# Altium schematic sheet UBX_NORA_B1_SCHEM_0006_Accessories.SchDoc
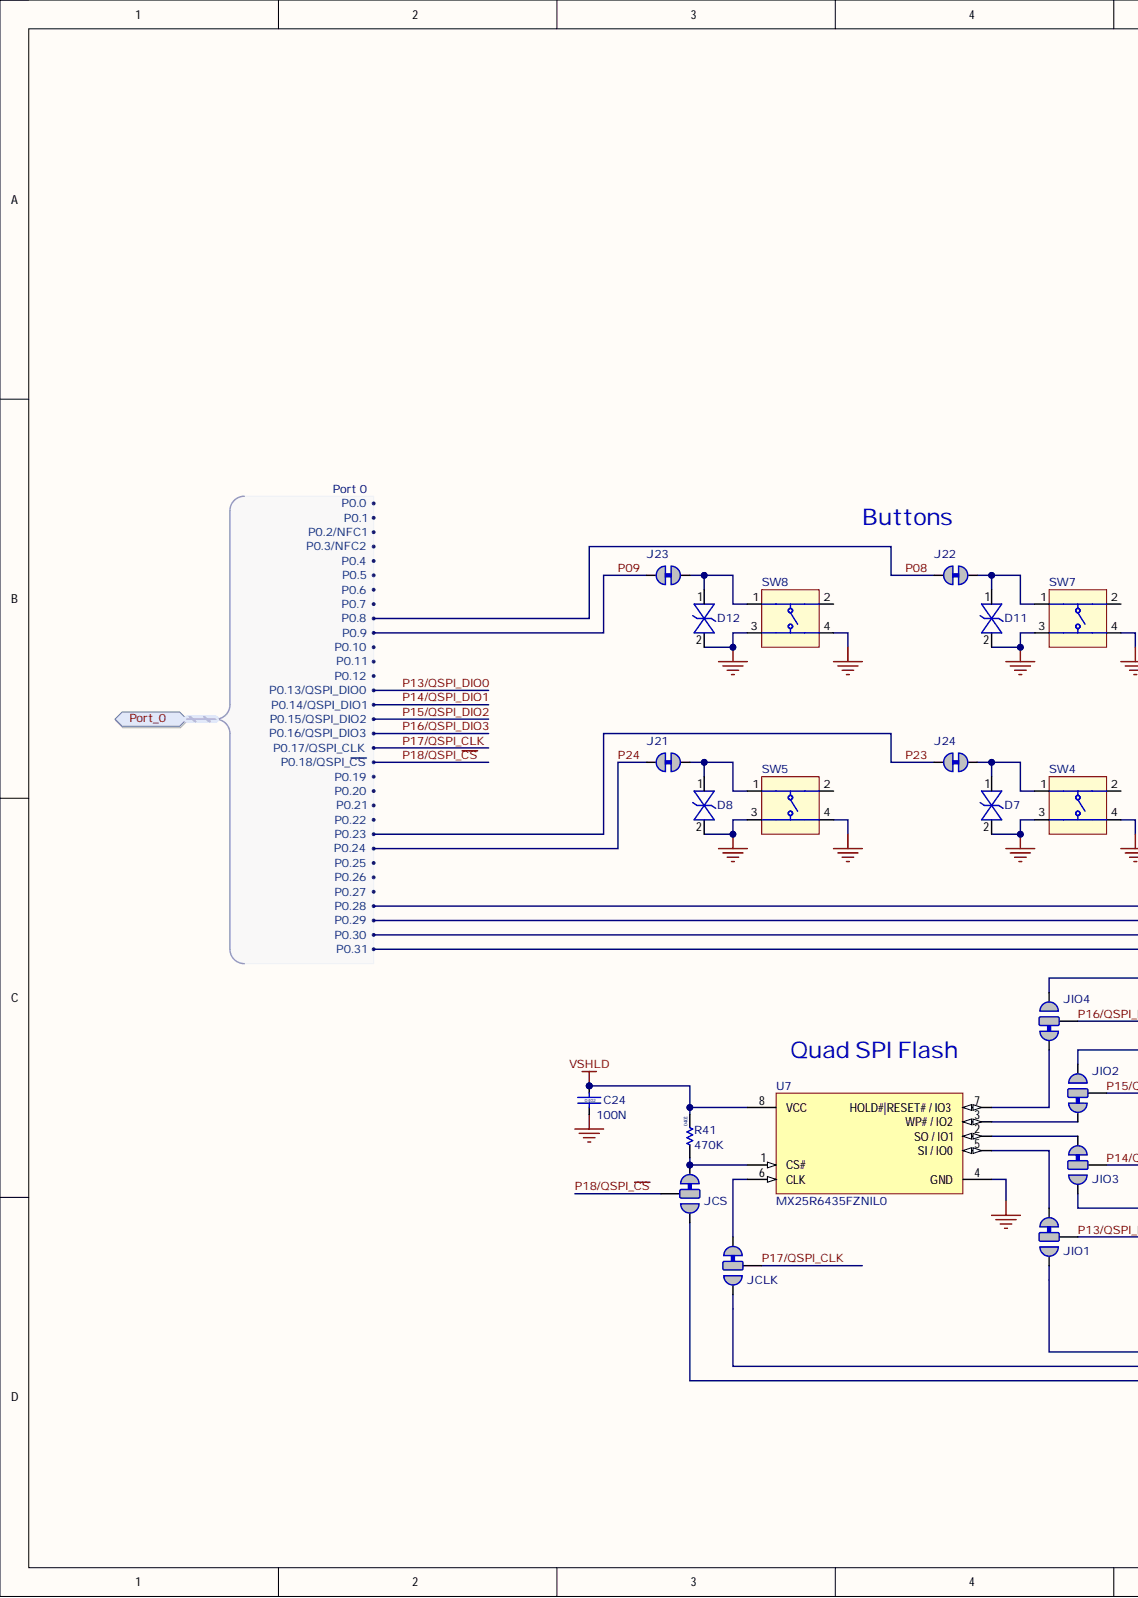
[diagram p0: UBX_NORA_B1_SCHEM_0006_Accessories.SchDoc, left]
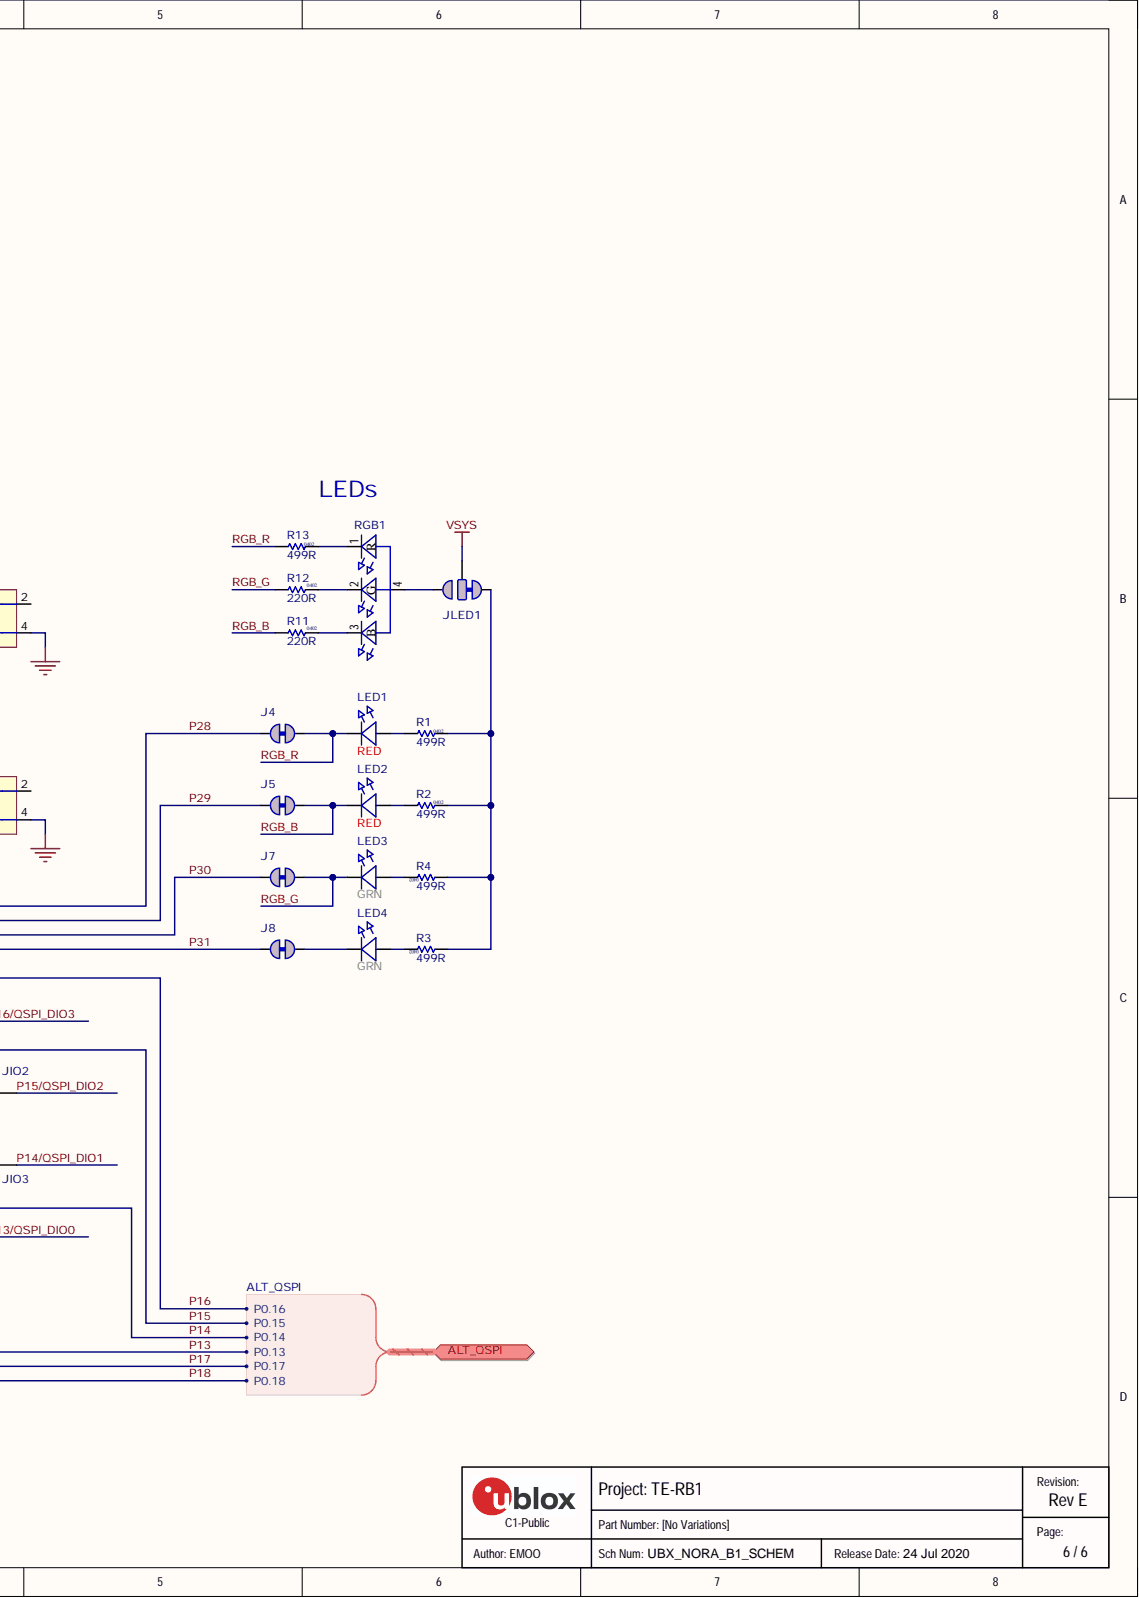
[diagram p1: UBX_NORA_B1_SCHEM_0006_Accessories.SchDoc, right]

## Parts
C24  value 100N  Size: 0402  [Cap 0402 HD]  (hidden comment: UBXH01-2021002100N)
D7  UBXH07-0000034  [SOD-923]  (value not drawn)
D8  UBXH07-0000034  [SOD-923]  (value not drawn)
D11  UBXH07-0000034  [SOD-923]  (value not drawn)
D12  UBXH07-0000034  [SOD-923]  (value not drawn)
J4  UBXH00-0000084  [Solderbridge-Short]  (value not drawn)
J5  UBXH00-0000084  [Solderbridge-Short]  (value not drawn)
J7  UBXH00-0000084  [Solderbridge-Short]  (value not drawn)
J8  UBXH00-0000084  [Solderbridge-Short]  (value not drawn)
J21  UBXH00-0000084  [Solderbridge-Short]  (value not drawn)
J22  UBXH00-0000084  [Solderbridge-Short]  (value not drawn)
J23  UBXH00-0000084  [Solderbridge-Short]  (value not drawn)
J24  UBXH00-0000084  [Solderbridge-Short]  (value not drawn)
JCLK  UBXH00-0000083  [Solderbridge-Option]  (value not drawn)
JCS  UBXH00-0000083  [Solderbridge-Option]  (value not drawn)
JIO1  UBXH00-0000083  [Solderbridge-Option]  (value not drawn)
JIO2  UBXH00-0000083  [Solderbridge-Option]  (value not drawn)
JIO3  UBXH00-0000083  [Solderbridge-Option]  (value not drawn)
JIO4  UBXH00-0000083  [Solderbridge-Option]  (value not drawn)
JLED1  UBXH00-0000083  [Solderbridge-Option]  (value not drawn)
LED1  value RED  Color: RED  [LED_0603_RED]  (hidden comment: UBXH11-0000000)
LED2  value RED  Color: RED  [LED_0603_RED]  (hidden comment: UBXH11-0000000)
LED3  Color: GRN  [LED_0603_GREEN]  (hidden comment: UBXH11-0000001)
LED4  Color: GRN  [LED_0603_GREEN]  (hidden comment: UBXH11-0000001)
R1  value 499R  Size: 0402  [RES 0402 HD]  (hidden comment: UBXH18-0000269499R)
R2  value 499R  Size: 0402  [RES 0402 HD]  (hidden comment: UBXH18-0000269499R)
R3  value 499R  Size: 0402  [RES 0402 HD]  (hidden comment: UBXH18-0000269499R)
R4  value 499R  Size: 0402  [RES 0402 HD]  (hidden comment: UBXH18-0000269499R)
R11  value 220R  Size: 0402  [RES 0402 HD]  (hidden comment: UBXH18-0008033220R)
R12  value 220R  Size: 0402  [RES 0402 HD]  (hidden comment: UBXH18-0008033220R)
R13  value 499R  Size: 0402  [RES 0402 HD]  (hidden comment: UBXH18-0000269499R)
R41  value 470K  Size: 0402  [RES 0402 HD]  (hidden comment: UBXH18-0008113470K)
RGB1  UBXH11-0000058  [LED_0606_RGB_4PIN]  (value not drawn)
SW4  UBXH19-0000035  [SW_TACT_SMT_6mm]  (value not drawn)
SW5  UBXH19-0000035  [SW_TACT_SMT_6mm]  (value not drawn)
SW7  UBXH19-0000035  [SW_TACT_SMT_6mm]  (value not drawn)
SW8  UBXH19-0000035  [SW_TACT_SMT_6mm]  (value not drawn)
U7  value MX25R6435FZNIL0  Manufacturer P/N: MX25R6435FZNIL0  [WSON1.27P-8Pin_W-Pad]  (hidden comment: UBXH13-0000138)

## Ports
ALT_QSPI, ALT_QSPI.P0.13, ALT_QSPI.P0.14, ALT_QSPI.P0.15, ALT_QSPI.P0.16, ALT_QSPI.P0.17, ALT_QSPI.P0.18, Port_0, Port_0.P0.0, Port_0.P0.1, Port_0.P0.2/NFC1, Port_0.P0.3/NFC2, Port_0.P0.4, Port_0.P0.5, Port_0.P0.6, Port_0.P0.7, Port_0.P0.8, Port_0.P0.9, Port_0.P0.10, Port_0.P0.11, Port_0.P0.12, Port_0.P0.13/QSPI_DIO0, Port_0.P0.14/QSPI_DIO1, Port_0.P0.15/QSPI_DIO2, Port_0.P0.16/QSPI_DIO3, Port_0.P0.17/QSPI_CLK, Port_0.P0.18/QSPI_~{CS}, Port_0.P0.19, Port_0.P0.20, Port_0.P0.21, Port_0.P0.22, Port_0.P0.23, Port_0.P0.24, Port_0.P0.25, Port_0.P0.26, Port_0.P0.27, Port_0.P0.28, Port_0.P0.29, Port_0.P0.30, Port_0.P0.31

## Nets
GND: C24.2 D7.2 D8.2 D11.2 D12.2 SW4.3 SW4.4 SW5.3 SW5.4 SW7.3 SW7.4 SW8.3 SW8.4 U7.4(GND)
VSHLD: C24.1 R41.2 U7.8(VCC)
VSYS: JLED1.2
P08: J22.2 ; port Port_0
P09: J23.2 ; port Port_0
P13: JIO1.3 ; port ALT_QSPI
P13/QSPI_DIO0: JIO1.2 ; port Port_0
P14: JIO3.3 ; port ALT_QSPI
P14/QSPI_DIO1: JIO3.2 ; port Port_0
P15: JIO2.3 ; port ALT_QSPI
P15/QSPI_DIO2: JIO2.2 ; port Port_0
P16: JIO4.3 ; port ALT_QSPI
P16/QSPI_DIO3: JIO4.2 ; port Port_0
P17: JCLK.3 ; port ALT_QSPI
P17/QSPI_CLK: JCLK.2 ; port Port_0
P18: JCS.3 ; port ALT_QSPI
P18/QSPI_~{CS}: JCS.2 ; port Port_0
P23: J24.2 ; port Port_0
P24: J21.2 ; port Port_0
P28: J4.2 ; port Port_0
P29: J5.2 ; port Port_0
P30: J7.2 ; port Port_0
P31: J8.2 ; port Port_0
RGB_B: J5.1 LED2.1 R11.1
RGB_G: J7.1 LED3.1 R12.1
RGB_R: J4.1 LED1.1 R13.1
NetD7_1: D7.1 J24.1 SW4.1
NetD8_1: D8.1 J21.1 SW5.1
NetD11_1: D11.1 J22.1 SW7.1
NetD12_1: D12.1 J23.1 SW8.1
NetJ8_1: J8.1 LED4.1
NetJCLK_1: JCLK.1 U7.6(CLK)
NetJCS_1: JCS.1 R41.1 U7.1(CS#)
NetJIO1_1: JIO1.1 U7.5(SI_/_IO0)
NetJIO2_1: JIO2.1 U7.3(WP#_/_IO2)
NetJIO3_1: JIO3.1 U7.2(SO_/_IO1)
NetJIO4_1: JIO4.1 U7.7(HOLD#|RESET#_/_IO3)
NetJLED1_1: JLED1.1 R1.2 R2.2 R3.1 R4.1
NetJLED1_3: JLED1.3 RGB1.4
NetLED1_2: LED1.2 R1.1
NetLED2_2: LED2.2 R2.1
NetLED3_2: LED3.2 R4.2
NetLED4_2: LED4.2 R3.2
NetR11_2: R11.2 RGB1.3(B)
NetR12_2: R12.2 RGB1.2(G)
NetR13_2: R13.2 RGB1.1(R)
N00001: ; port Port_0
N00002: ; port Port_0
N00003: ; port Port_0
N00004: ; port Port_0
N00005: ; port Port_0
N00006: ; port Port_0
N00007: ; port Port_0
N00008: ; port Port_0
N00009: ; port Port_0
N00010: ; port Port_0
N00011: ; port Port_0
N00012: ; port Port_0
N00013: ; port Port_0
N00014: ; port Port_0
N00015: ; port Port_0
N00016: ; port Port_0
N00017: ; port Port_0
N00018: ; port Port_0

Not connected: SW4 2; SW5 2; SW7 2; SW8 2

## Library data of the parts
C24 = UBXH01-2021002: CAP CER X5R 0402 100N 10% 10V; Manufacturer: Murata Manufacturing Company, Ltd.; Manufacturer P/N: GRM155R61A104KA01; Database Table Name: Passive - Capacitor; Editor: roland.rust; Env: GREEN; Mfg P/N Released: Yes; Mfg P/N Split: 100; Mfg P/N Status: NRND; Perferred: PREF; Permitted Use: Volume Products; Component Kind: Standard; Library Name: UBX_Parts_DB_Lib.DbLib; Manufacturer 2: Samsung Electro-Mechanics; Manufacturer P/N 2: CL05A104KP5NNN; Max Voltage: 10V; Mfg P/N Datasheet 2: https://wiki.u-blox.com/bin/view/Generic/Docs/DocumentSummary?index=28113; Mfg P/N Released 2: Yes; Mfg P/N Split 2: 0; Mfg P/N Status 2: Active; Part Number: UBXH01-2021002; Part Type: Capacitor; RefDes: C; Release Data: 2005-07-22T13:59:44; Signal Integrity: Cap Semi; Simulation: CAP; Tolerance: 10%; UBX Wiki: https://wiki.u-blox.com/bin/view/Operations/PartDetails?index=5759; Released by: roland.rust
D7 D8 D11 D12 = UBXH07-0000034: ESD PROTECTION DIODE ULTRA LOW LEAKAGE SOD923 ON SEMI 3V3; Manufacturer: ON Semiconductor; Manufacturer P/N: ESD9R3.3ST5G; Database Table Name: Discrete - Diode; Editor: pierpaolo.arban; Env: ROHS; Mfg P/N Released: Yes; Mfg P/N Split: 100; Mfg P/N Status: Active; Perferred: PREF; Permitted Use: Support Products; Released by: dario.antonaz; Component Kind: Standard; Library Name: UBX_Parts_DB_Lib.DbLib; Mfg P/N Datasheet: https://wiki.u-blox.com/bin/view/Generic/Docs/DocumentSummary?index=22449; Part Number: UBXH07-0000034; Part Type: Diode; RefDes: D; Release Data: 2015-04-23T14:51:11; UBX Wiki: https://wiki.u-blox.com/bin/view/Operations/PartDetails?index=22447; Value: 3V3
J4 J5 J7 J8 J21-J24 = UBXH00-0000084: SOLDERBRIDGE-SHORT; Database Table Name: Printed Circuit Element - Connector; Editor: aneeb.sohail; Env: GREEN; Perferred: PREF; Permitted Use: Volume Products; Component Kind: Net Tie; Library Name: UBX_Parts_DB_Lib.DbLib; Max Operating Temp: 155°C; Min Operating Temp: -55°C; Part Number: UBXH00-0000084; Part Type: Connector; RefDes: J; UBX Wiki: https://wiki.u-blox.com/bin/view/Operations/PartDetails?index=36363
JCLK JCS JIO1-JIO4 JLED1 = UBXH00-0000083: SOLDERBRIDGE-OPTION; Database Table Name: Printed Circuit Element - Connector; Editor: aneeb.sohail; Env: GREEN; Perferred: PREF; Permitted Use: Volume Products; Component Kind: Net Tie; Library Name: UBX_Parts_DB_Lib.DbLib; Max Operating Temp: 155°C; Min Operating Temp: -55°C; Part Number: UBXH00-0000083; Part Type: Connector; RefDes: J; UBX Wiki: https://wiki.u-blox.com/bin/view/Operations/PartDetails?index=36362
LED1 LED2 = UBXH11-0000000: LED SMD 0603 ULTRABRIGHT LITE-ON LTST-C190KRKT RED 30mA; Manufacturer: Lite-on Technology Corporation; Database Table Name: Discrete - LED; Editor: massimo.vlacci; Env: ROHS; Mfg P/N Released: Yes; Mfg P/N Split: 100; Mfg P/N Status: Active; Permitted Use: Support Products; Released by: sandro.sestan; Component Kind: Standard; Library Name: UBX_Parts_DB_Lib.DbLib; Manufacturer P/N: LTST-C190KRKT; Max Current: 30mA; Mfg P/N Datasheet: https://wiki.u-blox.com/bin/view/Generic/Docs/DocumentSummary?index=16221; Part Number: UBXH11-0000000; Part Type: LED; Perferred: N/A; RefDes: DS; Release Data: 2009-12-03T15:33:11; Size: 0603; UBX Wiki: https://wiki.u-blox.com/bin/view/Operations/PartDetails?index=7900
LED3 LED4 = UBXH11-0000001: LED SMD 0603 GREEN 2.5V 20mA; Manufacturer: Kingbright; Manufacturer P/N: APT1608SGC; Database Table Name: Discrete - LED; Editor: gianni.falleroni; Env: ROHS; Mfg P/N Released: Yes; Mfg P/N Split: 50; Mfg P/N Status: Active; Permitted Use: Support Products; Released by: alex.claville; Component Kind: Standard; Library Name: UBX_Parts_DB_Lib.DbLib; Manufacturer 2: Lite-on Technology Corporation; Manufacturer P/N 2: LTST-C190KGKT; Max Current: 20mA; Max Voltage: 2.5V; Mfg P/N Datasheet: https://wiki.u-blox.com/bin/view/Generic/Docs/DocumentSummary?index=37527; Mfg P/N Datasheet 2: https://wiki.u-blox.com/bin/view/Generic/Docs/DocumentSummary?index=16220; Mfg P/N Released 2: Yes; Mfg P/N Split 2: 50; Mfg P/N Status 2: Active; Part Number: UBXH11-0000001; Part Type: LED; Perferred: N/A; RefDes: DS; Release Data: 2022-09-27T14:26:54; Size: 0603; UBX Wiki: https://wiki.u-blox.com/bin/view/Operations/PartDetails?index=7902; Value: GREEN
R1-R4 R13 = UBXH18-0000269: RES THICK FILM CHIP 0402 499R 1% 100mW; Manufacturer: KOA; Manufacturer P/N: RK73H1ETTP4990F; Database Table Name: Passive - Resistor; Editor: pierpaolo.arban; Env: ROHS; Mfg P/N Released: No; Mfg P/N Split: 100; Mfg P/N Status: Active; Perferred: PREF; Permitted Use: Volume Products; Component Kind: Standard; Library Name: UBX_Parts_DB_Lib.DbLib; Max Operating Temp: 125°C; Max Power: 100mW; Mfg P/N Datasheet: https://wiki.u-blox.com/bin/view/Generic/Docs/DocumentSummary?index=8222; Min Operating Temp: -55°C; Part Number: UBXH18-0000269; Part Type: Resistor; RefDes: R; Signal Integrity: Res; Simulation: RESISTOR; Tolerance: 1%; UBX Wiki: https://wiki.u-blox.com/bin/view/Operations/PartDetails?index=25384
R11 R12 = UBXH18-0008033: RES THICK FILM CHIP 0402 220R 5% 100mW; Manufacturer: ROHM; Manufacturer P/N: MCR01MZPJ221; Database Table Name: Passive - Resistor; Editor: roland.rust; Env: ROHS; Mfg P/N Released: Yes; Mfg P/N Split: 100; Mfg P/N Status: Active; Perferred: PREF; Permitted Use: Volume Products; Component Kind: Standard; Library Name: UBX_Parts_DB_Lib.DbLib; Manufacturer 2: KOA; Manufacturer 3: YAGEO; Manufacturer P/N 2: RK73B1ETTP221J; Manufacturer P/N 3: 2322_705_87221_L; Max Power: 100mW; Mfg P/N Released 2: Yes; Mfg P/N Released 3: Yes; Mfg P/N Split 2: 0; Mfg P/N Split 3: 0; Mfg P/N Status 2: Active; Mfg P/N Status 3: NRND; Part Number: UBXH18-0008033; Part Type: Resistor; RefDes: R; Release Data: 2009-04-24T14:36:39; Signal Integrity: Res; Simulation: RESISTOR; Tolerance: 5%; UBX Wiki: https://wiki.u-blox.com/bin/view/Operations/PartDetails?index=6914; Released by: roland.rust
R41 = UBXH18-0008113: RES THICK FILM CHIP 0402 470K 5% 100mW; Manufacturer: KOA; Manufacturer P/N: RK73B1ETTD474J; Database Table Name: Passive - Resistor; Editor: martin.frauchiger; Env: ROHS; Mfg P/N Released: Yes; Mfg P/N Split: 100; Mfg P/N Status: NRND; Perferred: PREF; Permitted Use: Volume Products; Component Kind: Standard; Library Name: UBX_Parts_DB_Lib.DbLib; Manufacturer 2: YAGEO; Manufacturer P/N 2: 2322_705_87474_L; Max Power: 100mW; Mfg P/N Released 2: Yes; Mfg P/N Split 2: 0; Mfg P/N Status 2: Obsolete; Part Number: UBXH18-0008113; Part Type: Resistor; RefDes: R; Release Data: 2005-08-19T10:42:45; Signal Integrity: Res; Simulation: RESISTOR; Tolerance: 5%; UBX Wiki: https://wiki.u-blox.com/bin/view/Operations/PartDetails?index=7042; Released by: martin.frauchiger
RGB1 = UBXH11-0000058: LED SMD RGB 0606 COMMON ANODE LITE-ON LTST-C19HE1WT 5V 20mA; Manufacturer: Lite-on Technology Corporation; Database Table Name: Discrete - LED; Editor: eric.moog; Env: ROHS; Mfg P/N Released: No; Mfg P/N Split: 100; Mfg P/N Status: Active; Permitted Use: Support Products; Component Kind: Standard; Library Name: UBX_Parts_DB_Lib.DbLib; Manufacturer P/N: LTST-C19HE1WT; Max Current: 20mA; Max Operating Temp: 70°C; Max Voltage: 5V; Mfg P/N Datasheet: https://wiki.u-blox.com/bin/view/Generic/Docs/DocumentSummary?index=38664; Min Operating Temp: -15°C; Part Number: UBXH11-0000058; Part Type: LED; Perferred: N/A; RefDes: DS; UBX Wiki: https://wiki.u-blox.com/bin/view/Operations/PartDetails?index=38590; Value: LTST-C19HE1WT
SW4 SW5 SW7 SW8 = UBXH19-0000035: TACTILE SWITCH SPST SINGLE SMD; Manufacturer: C&K; Manufacturer P/N: PTS645SM43SMTR92LFS; Database Table Name: Electromechanical - Switch; Editor: enric.suchanek; Env: ROHS; Mfg P/N Released: No; Mfg P/N Split: 100; Mfg P/N Status: Active; Perferred: PREF; Permitted Use: Internal Use; Released by: charlotte.biber; Value: PTS645SM43SMTR92LFS; Component Kind: Standard; Library Name: UBX_Parts_DB_Lib.DbLib; Max Operating Temp: 85°C; Mfg P/N Datasheet: https://wiki.u-blox.com/bin/view/Generic/Docs/DocumentSummary?index=32053; Min Operating Temp: -40°C; Part Number: UBXH19-0000035; Part Type: Switch; RefDes: S; Release Data: 2021-08-24T16:41:59; UBX Wiki: https://wiki.u-blox.com/bin/view/Operations/PartDetails?index=32051
U7 = UBXH13-0000138: MACRONIX MX25R6435FZNIL0 64MBIT SERIAL QUAD I/O SPI FLASH MEMORY 1.65 - 3.6V 8-WDFN 6X5MM; Manufacturer: Macronix International Co.,Ltd.; Database Table Name: IC - Memory; Editor: eric.moog; Env: ROHS; Mfg P/N Released: No; Mfg P/N Split: 100; Mfg P/N Status: Active; Perferred: PREF; Permitted Use: Support Products; Component Kind: Standard; Library Name: UBX_Parts_DB_Lib.DbLib; Max Operating Temp: 85°C; Mfg P/N Datasheet: https://wiki.u-blox.com/bin/view/Generic/Docs/DocumentSummary?index=38929; Min Operating Temp: -40°C; Part Number: UBXH13-0000138; Part Type: Memory; RefDes: U; UBX Wiki: https://wiki.u-blox.com/bin/view/Operations/PartDetails?index=38927
